# Altium schematic sheet sunsensor.SchDoc(sunsensor)
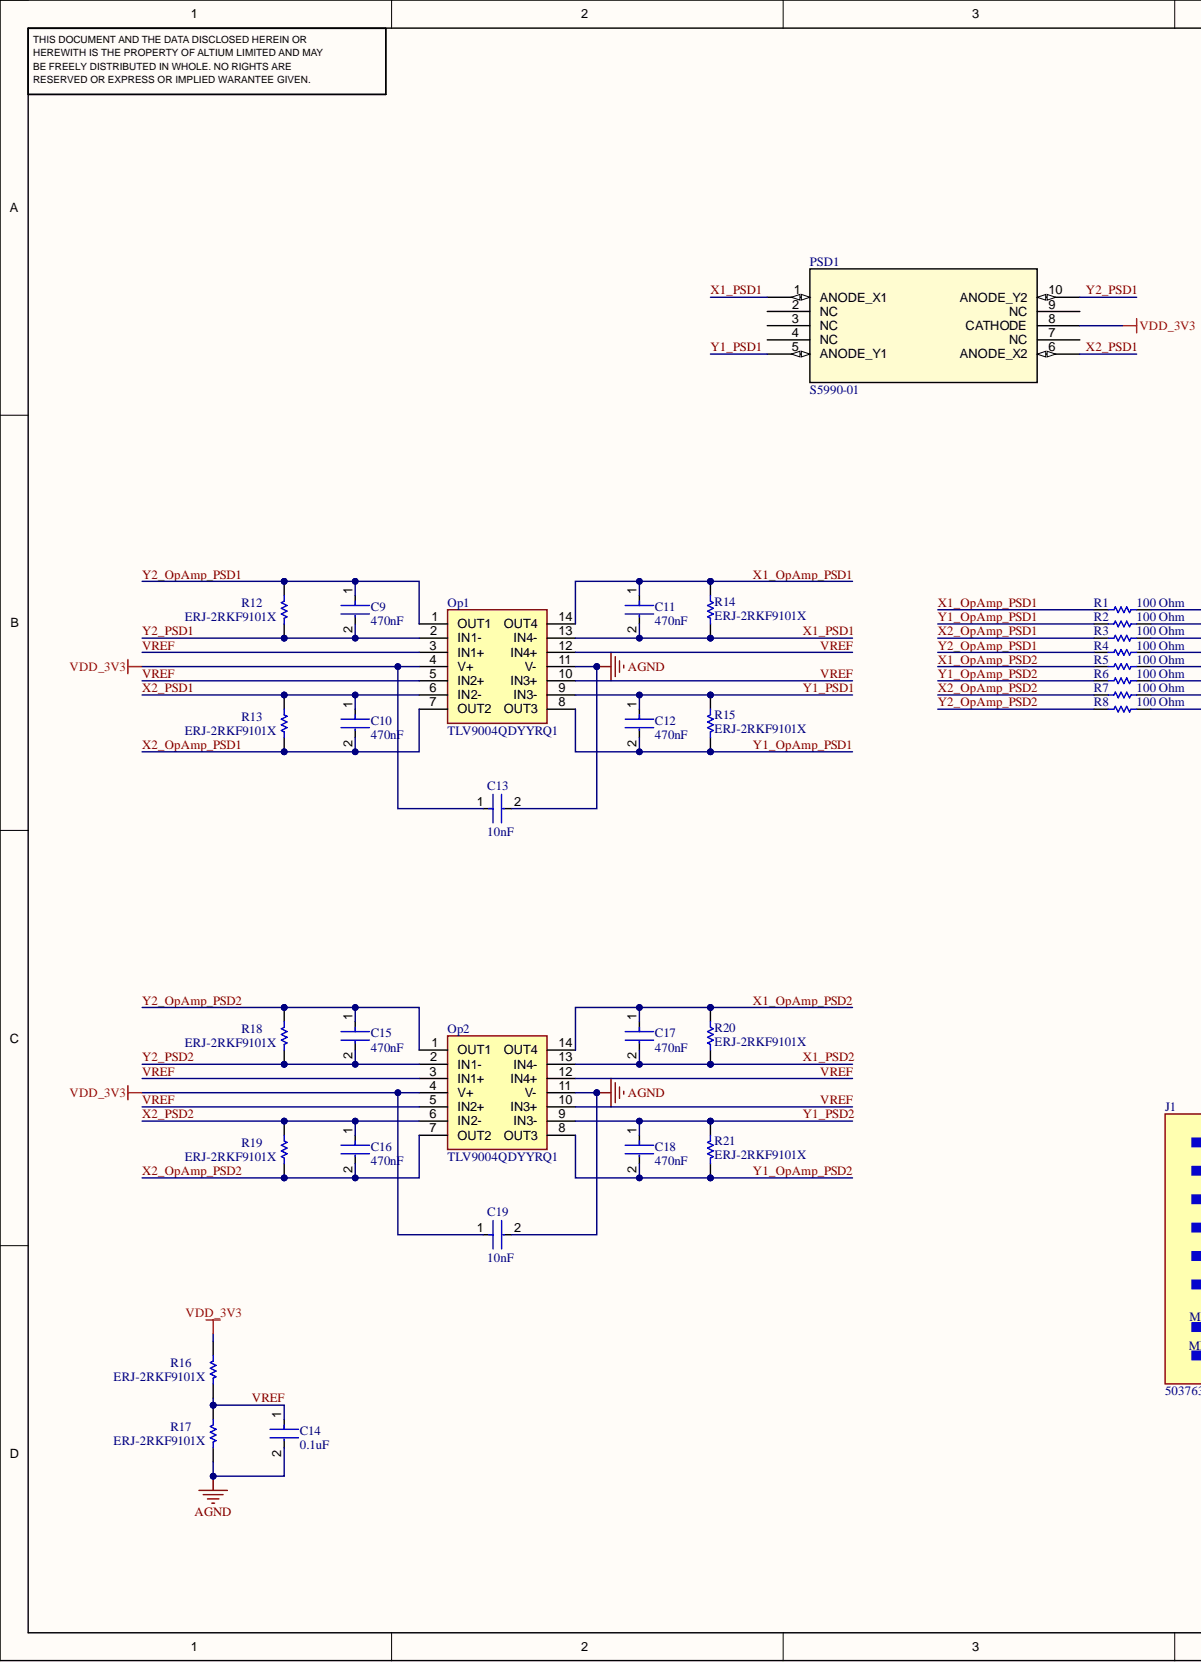
[diagram p0: sunsensor.SchDoc, left]
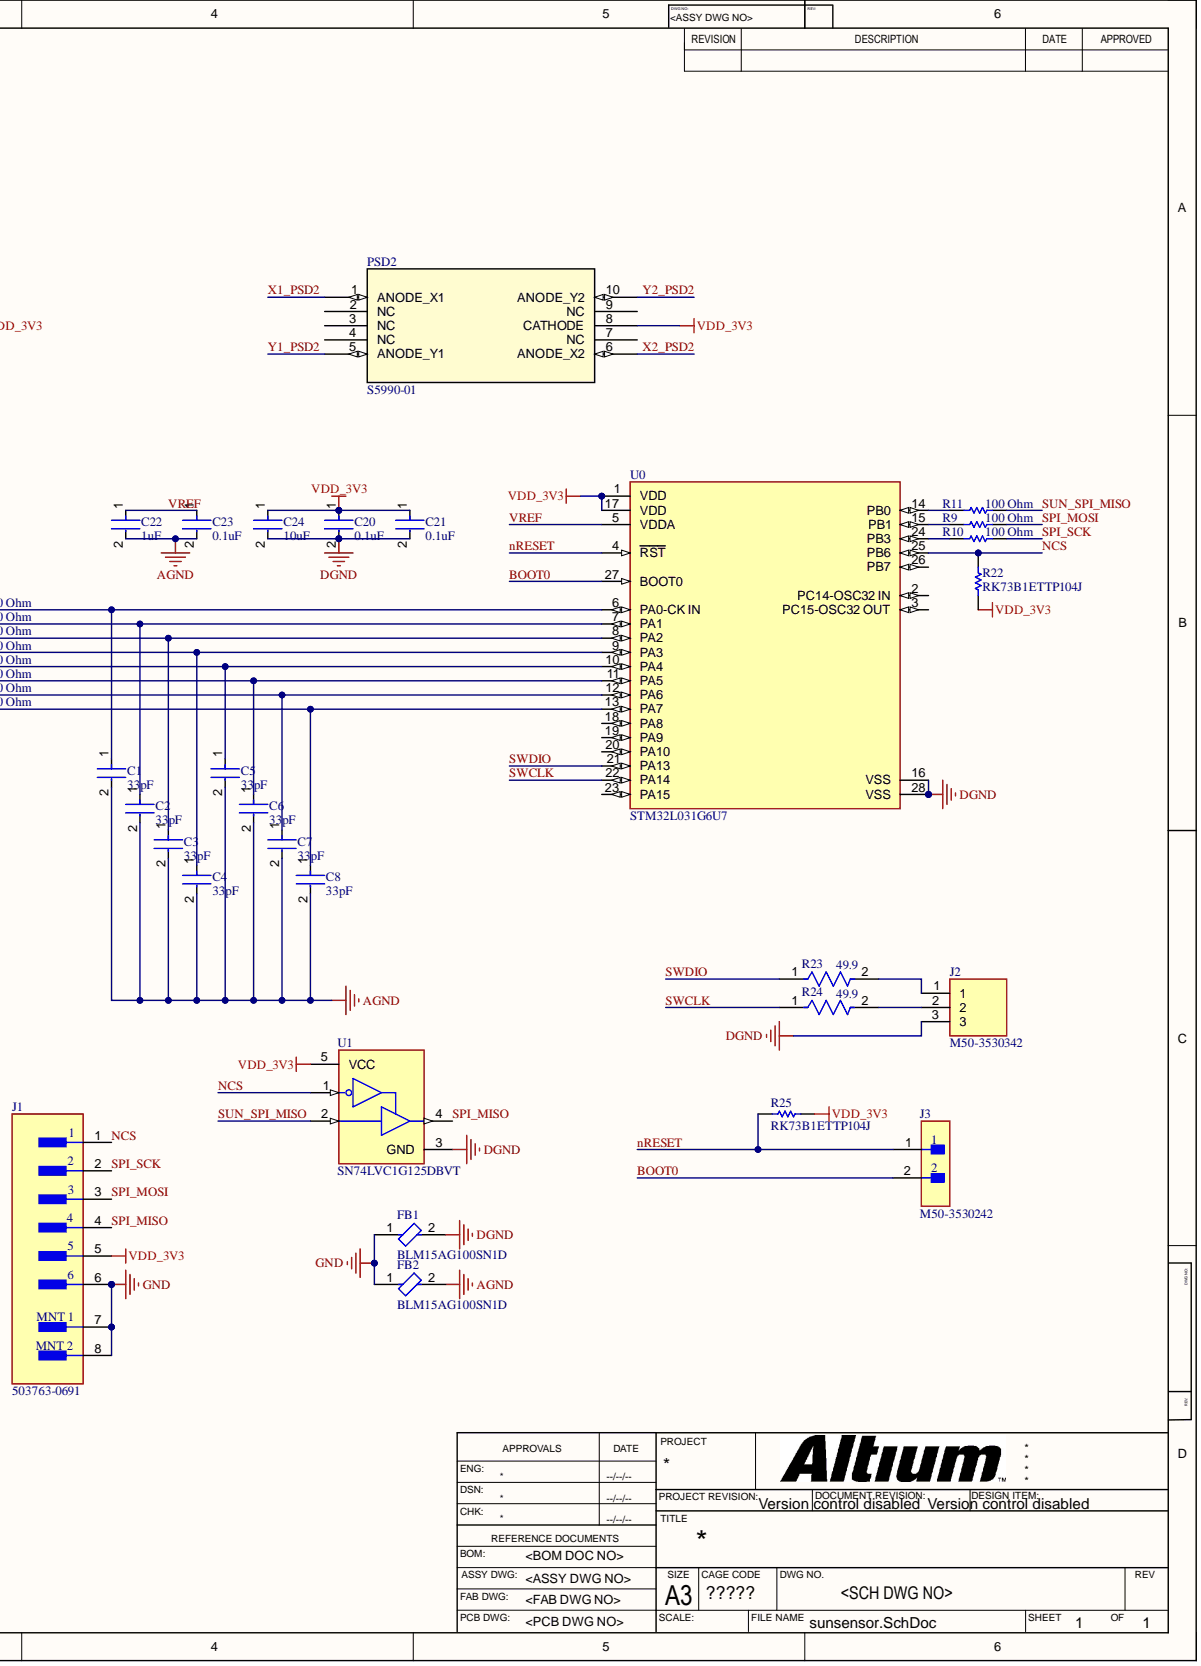
[diagram p1: sunsensor.SchDoc, right]

## Parts
C1  33pF
C2  33pF
C3  33pF
C4  33pF
C5  33pF
C6  33pF
C7  33pF
C8  33pF
C9  470nF
C10  470nF
C11  470nF
C12  470nF
C13  10nF
C14  0.1uF
C15  470nF
C16  470nF
C17  470nF
C18  470nF
C19  10nF
C20  0.1uF
C21  0.1uF
C22  1uF
C23  0.1uF
C24  10uF
FB1  BLM15AG100SN1D
FB2  BLM15AG100SN1D
J1  503763-0691
J2  M50-3530342
J3  M50-3530242
Op1  TLV9004QDYYRQ1
Op2  TLV9004QDYYRQ1
PSD1  S5990-01
PSD2  S5990-01
R1  100 Ohm
R2  100 Ohm
R3  100 Ohm
R4  100 Ohm
R5  100 Ohm
R6  100 Ohm
R7  100 Ohm
R8  100 Ohm
R9  100 Ohm
R10  100 Ohm
R11  100 Ohm
R12  ERJ-2RKF9101X
R13  ERJ-2RKF9101X
R14  ERJ-2RKF9101X
R15  ERJ-2RKF9101X
R16  ERJ-2RKF9101X
R17  ERJ-2RKF9101X
R18  ERJ-2RKF9101X
R19  ERJ-2RKF9101X
R20  ERJ-2RKF9101X
R21  ERJ-2RKF9101X
R22  RK73B1ETTP104J
R23  49.9
R24  49.9
R25  RK73B1ETTP104J
U0  STM32L031G6U7
U1  SN74LVC1G125DBVT

## Nets
AGND: C1.2 C2.2 C3.2 C4.2 C5.2 C6.2 C7.2 C8.2 C13.2 C14.2 C19.2 C22.2 C23.2 FB2.2 Op1.11(V-) Op2.11(V-) R17.1
DGND: C20.2 C21.2 C24.2 FB1.2 J2.3 U0.16(VSS) U0.28(VSS) U1.3(GND)
GND: FB1.1 FB2.1 J1.6 J1.7 J1.8
VDD_3V3: C13.1 C19.1 C20.1 C21.1 C24.1 J1.5 Op1.4(V+) Op2.4(V+) PSD1.8(CATHODE) PSD2.8(CATHODE) R16.2 R22.1 R25.1 U0.1(VDD) U0.17(VDD) U1.5(VCC)
BOOT0: J3.2 U0.27(BOOT0)
NCS: J1.1 R22.2 U0.25(PB6) U1.1
nRESET: J3.1 R25.2 U0.4(RST)
SPI_MISO: J1.4 U1.4
SPI_MOSI: J1.3 R9.1
SPI_SCK: J1.2 R10.1
SUN_SPI_MISO: R11.2 U1.2
SWCLK: R24.1 U0.22(PA14)
SWDIO: R23.1 U0.21(PA13)
VREF: C14.1 C22.1 C23.1 Op1.3(IN1+) Op1.5(IN2+) Op1.10(IN3+) Op1.12(IN4+) Op2.3(IN1+) Op2.5(IN2+) Op2.10(IN3+) Op2.12(IN4+) R16.1 R17.2 U0.5(VDDA)
X1_OpAmp_PSD1: C11.1 Op1.14(OUT4) R1.1 R14.2
X1_OpAmp_PSD2: C17.1 Op2.14(OUT4) R5.1 R20.2
X1_PSD1: C11.2 Op1.13(IN4-) PSD1.1(ANODE_X1) R14.1
X1_PSD2: C17.2 Op2.13(IN4-) PSD2.1(ANODE_X1) R20.1
X2_OpAmp_PSD1: C10.2 Op1.7(OUT2) R3.1 R13.2
X2_OpAmp_PSD2: C16.2 Op2.7(OUT2) R7.1 R19.2
X2_PSD1: C10.1 Op1.6(IN2-) PSD1.6(ANODE_X2) R13.1
X2_PSD2: C16.1 Op2.6(IN2-) PSD2.6(ANODE_X2) R19.1
Y1_OpAmp_PSD1: C12.2 Op1.8(OUT3) R2.1 R15.2
Y1_OpAmp_PSD2: C18.2 Op2.8(OUT3) R6.1 R21.2
Y1_PSD1: C12.1 Op1.9(IN3-) PSD1.5(ANODE_Y1) R15.1
Y1_PSD2: C18.1 Op2.9(IN3-) PSD2.5(ANODE_Y1) R21.1
Y2_OpAmp_PSD1: C9.1 Op1.1(OUT1) R4.1 R12.2
Y2_OpAmp_PSD2: C15.1 Op2.1(OUT1) R8.1 R18.2
Y2_PSD1: C9.2 Op1.2(IN1-) PSD1.10(ANODE_Y2) R12.1
Y2_PSD2: C15.2 Op2.2(IN1-) PSD2.10(ANODE_Y2) R18.1
NetC1_1: C1.1 R1.2 U0.6(PA0-CK_IN)
NetC2_1: C2.1 R2.2 U0.7(PA1)
NetC3_1: C3.1 R3.2 U0.8(PA2)
NetC4_1: C4.1 R4.2 U0.9(PA3)
NetC5_1: C5.1 R5.2 U0.10(PA4)
NetC6_1: C6.1 R6.2 U0.11(PA5)
NetC7_1: C7.1 R7.2 U0.12(PA6)
NetC8_1: C8.1 R8.2 U0.13(PA7)
NetJ2_1: J2.1 R23.2
NetJ2_2: J2.2 R24.2
NetR9_2: R9.2 U0.15(PB1)
NetR10_2: R10.2 U0.24(PB3)
NetR11_1: R11.1 U0.14(PB0)

Not connected: PSD1 2(NC) 3(NC) 4(NC) 7(NC) 9(NC); PSD2 2(NC) 3(NC) 4(NC) 7(NC) 9(NC); U0 2(PC14-OSC32_IN) 3(PC15-OSC32_OUT) 18(PA8) 19(PA9) 20(PA10) 23(PA15) 26(PB7)

## Library data of the parts
C1-C8 = CMP-2008-03563-2: CAP CER 33PF 50V C0G/NP0 0402; Manufacturer: Kemet; Manufacturer Part Number: C0402C330F5GACTU; Material: Ceramic; Temperature Characteristic: C0G; Temperature Coefficient: 15%; Tolerance: 0.01; Automotive: No; Capacitance: 33 pF; Category: Cap; Component Kind: Standard; ComponentLink2Description: Datasheet; ComponentLink2URL: https://content.kemet.com/datasheets/KEM_C1002_X7R_SMD.pdf; Device Class L1: Passive Components; Device Class L2: Capacitors; Device Class L3: Ceramic Capacitors; Digikey Description: CAP CER 33PF 50V C0G/NP0 0402; Height: 0.55mm; Ipc Land Pattern Name: CAPC10050X50; Lead Free: Yes; Library Name: Altium Content Vault; Mouser Description: Multilayer Ceramic Capacitors MLCC - SMD/SMT 50volts 33pF C0G 1%; Octopart: https://octopart.com/c0402c330f5gactu-kemet-17141442; Package: C0402-BB; RoHS: TRUE; Temperature: -55°C to +125°C; Temperature Range High: +125°C; Temperature Range Low: -55°C; Value: 33 pF; Voltage Rating: 50 V
C9-C12 C15-C18 = CMP-06035-042090-1: Chip Multilayer Ceramic Capacitors for General Purpose, 0402, 0.47uF, X7R, 15%, 10%, 10V; Capacitance: 470nF; Case/Package: 0402; Component Kind: Standard; Height: 0.3mm; Lead Free: Lead Free; Length: 1mm; Library Name: Altium Content Vault; Material: Ceramic; Thickness: 500um; Tolerance: 10%; Voltage Rating (DC): 10V; Width: 0.5mm
C13 C19 = CMP-2008-02109-2: CAP CER 10000PF 50V X7R 0402; Manufacturer: Kemet; Manufacturer Part Number: C0402C103K5RACTU; Capacitance: 10,000 pF; Material: Ceramic; Temperature Coefficient: 15%; Tolerance: 0.1; Value: 10,000 pF; Automotive: No; Category: Cap; Component Kind: Standard; ComponentLink2Description: Datasheet; ComponentLink2URL: https://content.kemet.com/datasheets/KEM_C1002_X7R_SMD.pdf; Device Class L1: Passive Components; Device Class L2: Capacitors; Device Class L3: Ceramic Capacitors; Digikey Description: CAP CER 10000PF 50V X7R 0402; Height: 0.55mm; Ipc Land Pattern Name: CAPC10050X50; Lead Free: Yes; Library Name: Altium Content Vault; Mouser Description: Capacitor; Ceramic; Cap; .010uF; Tol 10%; SMT; Vol-Rtg 50V; X7R; Tape and Reel; Octopart: https://octopart.com/c0402c103k5ractu-kemet-95985; Package: C0402-BB; RoHS: TRUE; Temperature: -55°C to +125°C; Temperature Characteristic: X7R; Temperature Range High: +125°C; Temperature Range Low: -55°C; Voltage Rating: 50 V
C14 C20 C21 C23 = CMP-2008-02108-2: CAP CER 0.1UF 16V X7R 0402; Manufacturer: Kemet; Manufacturer Part Number: C0402C104K4RACTU; Capacitance: 0.10 μF; Material: Ceramic; Temperature Coefficient: 15%; Tolerance: 0.1; Value: 0.10 μF; Automotive: No; Category: Cap; Component Kind: Standard; ComponentLink2Description: Datasheet; ComponentLink2URL: https://content.kemet.com/datasheets/KEM_C1002_X7R_SMD.pdf; Device Class L1: Passive Components; Device Class L2: Capacitors; Device Class L3: Ceramic Capacitors; Digikey Description: CAP CER 0.1UF 16V X7R 0402; Height: 0.55mm; Ipc Land Pattern Name: CAPC10050X50; Lead Free: Yes; Library Name: Altium Content Vault; Mouser Description: Cap Ceramic 0.1uF 16V X7R 10% SMD 0402 125?C Paper T/R - Tape and Reel (Alt: C0402C104K4RAC TU); Octopart: https://octopart.com/c0402c104k4ractu-kemet-94158; Package: C0402-BB; RoHS: TRUE; Temperature: -55°C to +125°C; Temperature Characteristic: X7R; Temperature Range High: +125°C; Temperature Range Low: -55°C; Voltage Rating: 16 V
C22 = CMP-2008-02081-2: CAP CER 1UF 10V X5R 0402; Dielectric Material: Ceramic; Dissipation Factor: 5%; Tolerance: 10%; Capacitance: 1uF; Case Code (Imperial): 0402; Case Code (Metric): 1005; Case/Package: 0402; Component Kind: Standard; Construction: Flat; Contact Plating: Tin; Depth: 0.5mm; Dielectric: X5R; Height: 0.5mm; Lead Free: Lead Free; Length: 1mm; Library Name: Altium Content Vault; Max Operating Temperature: 85°C; Min Operating Temperature: -55°C; Mount: Surface Mount; Number of Pins: 2; Package Quantity: 1; Packaging: Tape and Reel; Radiation Hardening: No; REACH SVHC: No SVHC; RoHS Compliant: Yes; Series: C; Termination: SMD/SMT; Thickness: 0.022inch; Voltage: 10V; Voltage Rating: 10V; Voltage Rating (DC): 10V; Width: 0.5mm
C24 = CMP-03020-034678-1: CAP CER 10UF 6.3V X5R 0402; Dielectric Material: Ceramic; Tolerance: 20%; Capacitance: 10uF; Case Code (Imperial): 0402; Case Code (Metric): 1005; Case/Package: 0402; Component Kind: Standard; Dielectric: X5R; Lead Free: Lead Free; Length: 0.039inch; Library Name: Altium Content Vault; Max Operating Temperature: 85°C; Min Operating Temperature: -55°C; Mount: Surface Mount; Packaging: Cut Tape; REACH SVHC: No SVHC; RoHS Compliant: Yes; Thickness: 0.028inch; Voltage Rating: 6.3V; Voltage Rating (DC): 6.3V; Width: 0.02inch
FB1 FB2 = CMP-0686-00176-4: Chip Ferrite Bead, 0402, 10Ω @ 100MHz, 0.025Ω, 25%, 1A; DC Resistance (DCR): 25mR; Impedance: 10R; Number of Circuits: 1; Resistance: 50mR; Shielding: Unshielded; Case Code (Imperial): 0402; Case Code (Metric): 1005; Case/Package: 0402; Component Kind: Standard; Core Material: Ferrite; Current Rating: 1A; DC Current: 1A; Depth: 0.55mm; Height: 0.5mm; Lead Free: Lead Free; Length: 1mm; Library Name: Altium Content Vault; Material: Ferrite; Max Current Rating: 1A; Max DC Current: 1A; Max Operating Temperature: 125°C; Military Standard: Not; Min Operating Temperature: -55°C; Number of Lines: 1; Number of Pins: 2; Package Quantity: 1; Packaging: Tape and Reel; Radiation Hardening: No; REACH SVHC: No SVHC; Resistor Type: Ferrite Bead; RoHS Compliant: Yes; Termination: SMD/SMT; Test Frequency: 100MHz; Tolerance: 25%; Weight: 2.3E-05oz; Width: 0.5mm
J1 = CMP-04776-000530-1: CONN HEADER SMD R/A 6POS 1MM; Component Kind: Standard; Library Name: Altium Content Vault
J2 = M50-3530342: Connector; Manufacturer_Name: Harwin; Component Kind: Standard; Datasheet Link: https://cdn.harwin.com/pdfs/M50-353.pdf; Height: 4.3mm; Manufacturer_Part_Number: M50-3530342; Mouser Part Number: 855-M50-3530342; Mouser Price/Stock: https://www.mouser.co.uk/ProductDetail/Harwin/M50-3530342?qs=%252BdQmOuGyFcFOxjGK%2F4HnAg%3D%3D
J3 = CMP-15831-000040-1: CONN HEADER VERT 2POS 1.27MM; Component Kind: Standard; Library Name: Altium Content Vault
Op1 Op2 = TLV9004QDYYRQ1: Integrated Circuit; Manufacturer_Name: Texas Instruments; Arrow Part Number: TLV9004QDYYRQ1; Arrow Price/Stock: https://www.arrow.com/en/products/tlv9004qdyyrq1/texas-instruments?utm_currency=USD&region=nac; Component Kind: Standard; Datasheet Link: https://www.ti.com/lit/ds/symlink/tlv9004-q1.pdf?ts=1653903936376&ref_url=https%253A%252F%252Fwww.ti.com%252Fproduct%252FTLV9004-Q1%252Fpart-details%252FTLV9004QDYYRQ1; Height: 1.1mm; Manufacturer_Part_Number: TLV9004QDYYRQ1; Mouser Part Number: 595-TLV9004QDYYRQ1; Mouser Price/Stock: https://www.mouser.co.uk/ProductDetail/Texas-Instruments/TLV9004QDYYRQ1?qs=A6eO%252BMLsxmQxCVD4nSHH0g%3D%3D
PSD1 PSD2 = CMP-075-000001-1: No Description Available; Mfr_Name: Hamamatsu; Component Kind: Standard; Design Item ID: S5990-01; RefDes: RefDes; Source: S5990-01.SchLib; Type: Position Sensors - Angle, Linear Position Measuring; Library Name: Hitesh Bhoyar
R1-R11 = CMP-2002-06458-1: Manufacturer: Panasonic; Part Number: ERJ-U02F1000X; Component Kind: Standard; Library Name: Altium Content Vault
R12-R21 = CMP-1011-00619-2: Manufacturer: Panasonic; Component Kind: Standard; Library Name: Altium Content Vault; Part Number: ERJ-2RKF9101X
R22 R25 = CMP-2002-03715-1: Manufacturer: KOA Speer; Component Kind: Standard; Library Name: Altium Content Vault; Part Number: RK73B1ETTP104J
R23 R24 = CMP-2002-00969-2: RES SMD 49.9 OHM 1% 1/10W 0402; Max Power Dissipation: 100mW; Resistance: 49.9R; Temperature Coefficient: 100ppm/°C; Voltage Rating: 50V; Case Code (Imperial): 0402; Case Code (Metric): 1005; Case/Package: 0402; Component Kind: Standard; Construction: Rectangular; Contact Plating: Tin; Depth: 0.5mm; Features: Automotive AEC-Q200; Height: 0.35mm; Lead Free: Lead Free; Length: 1mm; Library Name: Altium Content Vault; Max Operating Temperature: 155°C; Military Standard: Not; Min Operating Temperature: -55°C; Mount: Surface Mount; Number of Terminations: 2; Package Quantity: 1; Packaging: Tape and Reel; Power Rating: 100mW; Radiation Hardening: No; Resistance Tolerance: 1%; Resistor Type: Thick Film; RoHS Compliant: Yes; Series: ERJ; Technology: THICK FILM; Termination: SMD/SMT; Tolerance: 1%; Voltage Rating (DC): 50V; Width: 0.02inch; Working Voltage: 50V
U0 = CMP-12105-000084-1: IC MCU 32BIT 32KB FLASH 28UFQFPN; Core Architecture: ARM; Frequency: 32MHz; Interface: I2C; Max Power Dissipation: 167mW; Max Supply Voltage: 3.6V; Min Supply Voltage: 1.8V; Number of I/Os: 21; Number of Timers/Counters: 4; Operating Supply Voltage: 3.3V; Oscillator Type: Internal; Peripherals: Brown-out Detect/Reset; RAM Size: 1KB; Watchdog Timer: Yes; Component Kind: Standard; Lead Free: Lead Free; Library Name: Altium Content Vault; Max Frequency: 32MHz; Max Operating Temperature: 105°C; Memory Size: 32KB; Memory Type: FLASH; Min Operating Temperature: -40°C; Mount: Surface Mount; Number of Pins: 28; Number of Programmable I/O: 21
U1 = CMP-0859-00018-4: IC BUF NON-INVERT 5.5V SOT23-5; Manufacturer: Texas Instruments; Logic Function Desc: Non-Inverting; Min Supply Voltage: 1.65V; Nominal Supply Current: 10uA; Number Of Circuits: 1; Propagation Delay: 4.2ns; Standoff Height: 0mm; Ambient Temperature Range High: +125°C; Ambient Temperature Range Low: -40°C; Automotive: No; Category: IC; Component Kind: Standard; ComponentLink2Description: Datasheet; ComponentLink2URL: http://www.ti.com/lit/ds/symlink/sn74lvc1g125.pdf; Device Class L1: Integrated Circuits (ICs); Device Class L2: Logic ICs; Device Class L3: Logic Gates; Digikey Description: IC BUF NON-INVERT 5.5V SOT23-5; Height: 1.45mm; Lead Free: Yes; Library Name: Altium Content Vault; Manufacturer Part Number: SN74LVC1G125DBVT; Max Supply Voltage: 5.5V; Mouser Description: Buffers & Line Drivers SNGL Bus Buffer Gate; Number Of Inputs: 1; Number Of Outputs: 1; Octopart: https://octopart.com/sn74lvc1g125dbvt-texas+instruments-1006799; Package: DBV0005A; RoHS: TRUE; Temperature: -40°C to +125°C; Temperature Range High: +125°C; Temperature Range Low: -40°C
